# Altium schematic sheet 07_LMS7002M_Power.SchDoc(07_LMS7002M_Power)
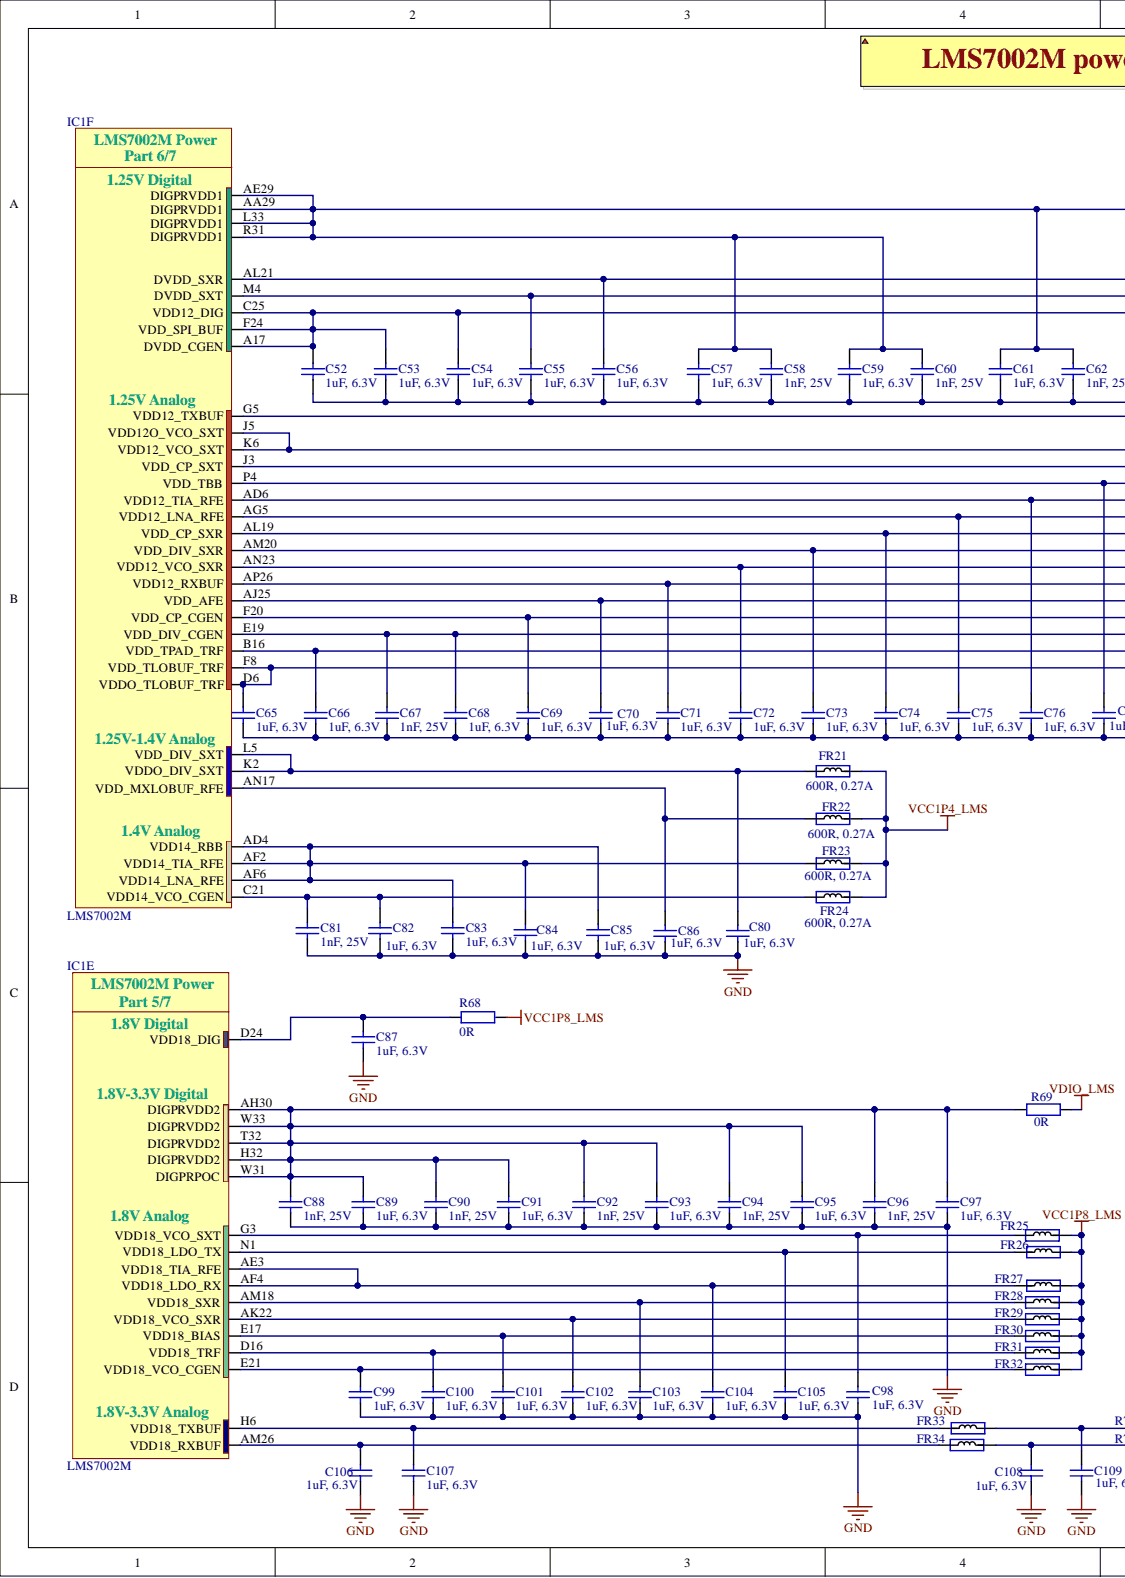
[diagram p0: 07_LMS7002M_Power.SchDoc, left]
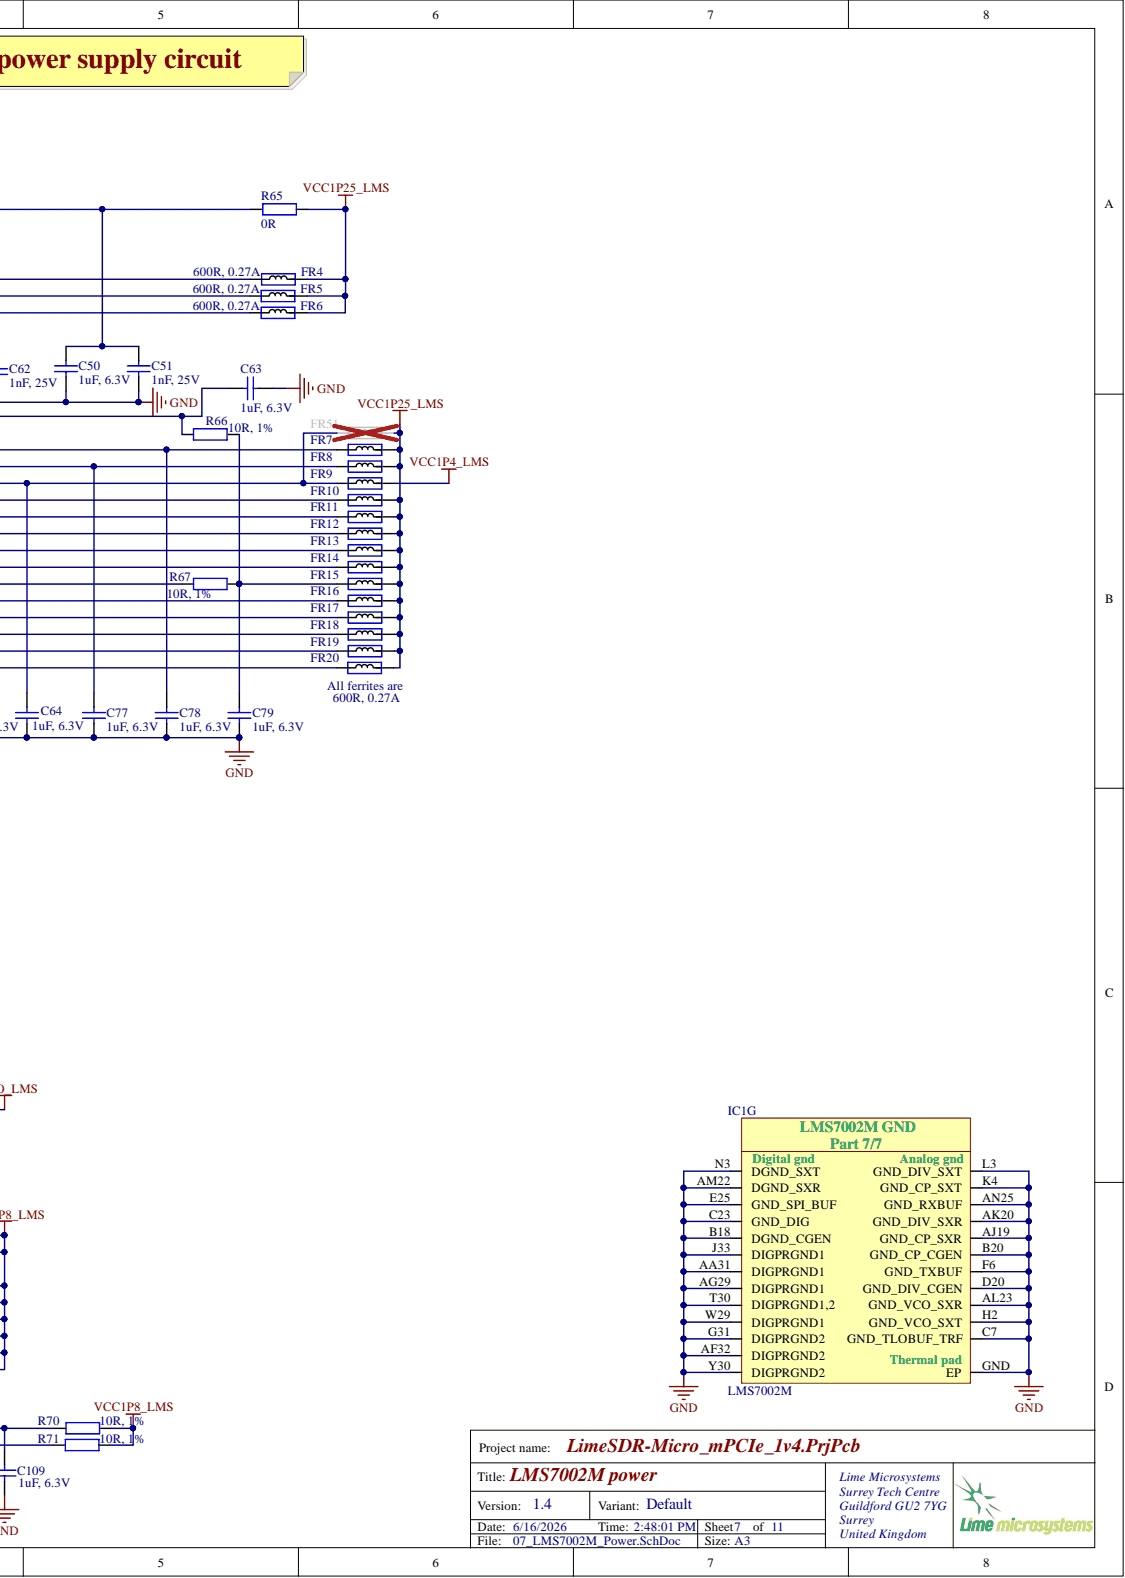
[diagram p1: 07_LMS7002M_Power.SchDoc, right]

## Parts
C50  1uF, 6.3V  [CAP0201]
C51  1nF, 25V  Voltage - Rated: 25V  [CAP0201]
C52  1uF, 6.3V  [CAP0201]
C53  1uF, 6.3V  Voltage - Rated: 6.3V  [CAP0201]
C54  1uF, 6.3V  Voltage - Rated: 6.3V  [CAP0201]
C55  1uF, 6.3V  Voltage - Rated: 6.3V  [CAP0201]
C56  1uF, 6.3V  Voltage - Rated: 6.3V  [CAP0201]
C57  1uF, 6.3V  [CAP0201]
C58  1nF, 25V  [CAP0201]
C59  1uF, 6.3V  [CAP0201]
C60  1nF, 25V  [CAP0201]
C61  1uF, 6.3V  [CAP0201]
C62  1nF, 25V  [CAP0201]
C63  1uF, 6.3V  Voltage - Rated: 6.3V  [CAP0201]
C64  1uF, 6.3V  Voltage - Rated: 6.3V  [CAP0201]
C65  1uF, 6.3V  [CAP0201]
C66  1uF, 6.3V  Voltage - Rated: 6.3V  [CAP0201]
C67  1nF, 25V  [CAP0201]
C68  1uF, 6.3V  [CAP0201]
C69  1uF, 6.3V  Voltage - Rated: 6.3V  [CAP0201]
C70  1uF, 6.3V  Voltage - Rated: 6.3V  [CAP0201]
C71  1uF, 6.3V  Voltage - Rated: 6.3V  [CAP0201]
C72  1uF, 6.3V  Voltage - Rated: 6.3V  [CAP0201]
C73  1uF, 6.3V  Voltage - Rated: 6.3V  [CAP0201]
C74  1uF, 6.3V  Voltage - Rated: 6.3V  [CAP0201]
C75  1uF, 6.3V  Voltage - Rated: 6.3V  [CAP0201]
C76  1uF, 6.3V  Voltage - Rated: 6.3V  [CAP0201]
C77  1uF, 6.3V  Voltage - Rated: 6.3V  [CAP0201]
C78  1uF, 6.3V  Voltage - Rated: 6.3V  [CAP0201]
C79  1uF, 6.3V  Voltage - Rated: 6.3V  [CAP0201]
C80  1uF, 6.3V  Voltage - Rated: 6.3V  [CAP0201]
C81  1nF, 25V  [CAP0201]
C82  1uF, 6.3V  [CAP0201]
C83  1uF, 6.3V  Voltage - Rated: 6.3V  [CAP0201]
C84  1uF, 6.3V  Voltage - Rated: 6.3V  [CAP0201]
C85  1uF, 6.3V  Voltage - Rated: 6.3V  [CAP0201]
C86  1uF, 6.3V  Voltage - Rated: 6.3V  [CAP0201]
C87  1uF, 6.3V  Voltage - Rated: 6.3V  [CAP0201]
C88  1nF, 25V  [CAP0201]
C89  1uF, 6.3V  [CAP0201]
C90  1nF, 25V  [CAP0201]
C91  1uF, 6.3V  [CAP0201]
C92  1nF, 25V  [CAP0201]
C93  1uF, 6.3V  [CAP0201]
C94  1nF, 25V  [CAP0201]
C95  1uF, 6.3V  [CAP0201]
C96  1nF, 25V  [CAP0201]
C97  1uF, 6.3V  [CAP0201]
C98  1uF, 6.3V  Voltage - Rated: 6.3V  [CAP0201]
C99  1uF, 6.3V  [CAP0201]
C100  1uF, 6.3V  Voltage - Rated: 6.3V  [CAP0201]
C101  1uF, 6.3V  Voltage - Rated: 6.3V  [CAP0201]
C102  1uF, 6.3V  Voltage - Rated: 6.3V  [CAP0201]
C103  1uF, 6.3V  Voltage - Rated: 6.3V  [CAP0201]
C104  1uF, 6.3V  Voltage - Rated: 6.3V  [CAP0201]
C105  1uF, 6.3V  Voltage - Rated: 6.3V  [CAP0201]
C106  1uF, 6.3V  Voltage - Rated: 6.3V  [CAP0201]
C107  1uF, 6.3V  Voltage - Rated: 6.3V  [CAP0201]
C108  1uF, 6.3V  Voltage - Rated: 6.3V  [CAP0201]
C109  1uF, 6.3V  Voltage - Rated: 6.3V  [CAP0201]
FR4  600R, 0.27A  [RES0201]
FR5  600R, 0.27A  [RES0201]
FR6  600R, 0.27A  [RES0201]
FR7  600R, 0.27A  [RES0201]
FR8  600R, 0.27A  [RES0201]
FR9  600R, 0.27A  [RES0201]
FR10  600R, 0.27A  [RES0201]
FR11  600R, 0.27A  [RES0201]
FR12  600R, 0.27A  [RES0201]
FR13  600R, 0.27A  [RES0201]
FR14  600R, 0.27A  [RES0201]
FR15  600R, 0.27A  [RES0201]
FR16  600R, 0.27A  [RES0201]
FR17  600R, 0.27A  [RES0201]
FR18  600R, 0.27A  [RES0201]
FR19  600R, 0.27A  [RES0201]
FR20  600R, 0.27A  [RES0201]
FR21  600R, 0.27A  [RES0201]
FR22  600R, 0.27A  [RES0201]
FR23  600R, 0.27A  [RES0201]
FR24  600R, 0.27A  [RES0201]
FR25  600R, 0.27A  [RES0201]
FR26  600R, 0.27A  [RES0201]
FR27  600R, 0.27A  [RES0201]
FR28  600R, 0.27A  [RES0201]
FR29  600R, 0.27A  [RES0201]
FR30  600R, 0.27A  [RES0201]
FR31  600R, 0.27A  [RES0201]
FR32  600R, 0.27A  [RES0201]
FR33  600R, 0.27A  [RES0201]
FR34  600R, 0.27A  [RES0201]
FR51  600R, 0.27A  [RES0201]
IC1  LMS7002M  [LMS7002M_v5_LESS_SILK]  (parts E,F,G)
R65  0R  [RES0402]
R66  10R, 1%  [RES0201]
R67  10R, 1%  [RES0201]
R68  0R  [RES0201]
R69  0R  [RES0402]
R70  10R, 1%  [RES0201]
R71  10R, 1%  [RES0201]

## Nets
GND: C50.2 C51.2 C52.2 C53.2 C54.2 C55.1 C56.2 C57.2 C58.2 C59.2 C60.2 C61.2 C62.2 C63.2 C64.2 C65.2 C66.2 C67.2 C68.2 C69.1 C70.2 C71.2 C72.2 C73.2 C74.2 C75.2 C76.1 C77.2 C78.1 C79.1 C80.2 C81.2 C82.2 C83.2 C84.2 C85.2 C86.2 C87.2 C88.2 C89.2 C90.2 C91.2 C92.2 C93.2 C94.2 C95.2 C96.2 C97.2 C98.2 C99.2 C100.2 C101.2 C102.2 C103.2 C104.2 C105.2 C106.2 C107.2 C108.2 C109.2 IC1.AA31(DIGPRGND1) IC1.AF32(DIGPRGND2) IC1.AG29(DIGPRGND1) IC1.AJ19(GND_CP_SXR) IC1.AK20(GND_DIV_SXR) IC1.AL23(GND_VCO_SXR) IC1.AM22(DGND_SXR) IC1.AN25(GND_RXBUF) IC1.B18(DGND_CGEN) IC1.B20(GND_CP_CGEN) IC1.C7(GND_TLOBUF_TRF) IC1.C23(GND_DIG) IC1.D20(GND_DIV_CGEN) IC1.E25(GND_SPI_BUF) IC1.F6(GND_TXBUF) IC1.G31(DIGPRGND2) IC1.GND(EP) IC1.H2(GND_VCO_SXT) IC1.J33(DIGPRGND1) IC1.K4(GND_CP_SXT) IC1.L3(GND_DIV_SXT) IC1.N3(DGND_SXT) IC1.T30(DIGPRGND1,2) IC1.W29(DIGPRGND1) IC1.Y30(DIGPRGND2)
VCC1P4_LMS: FR9.2 FR21.2 FR22.2 FR23.2 FR24.2
VCC1P8_LMS: FR25.2 FR26.1 FR27.1 FR28.2 FR29.2 FR30.2 FR31.2 FR32.2 R68.2 R70.2 R71.1
VCC1P25_LMS: FR4.2 FR5.2 FR6.2 FR7.2 FR8.2 FR10.2 FR11.2 FR12.2 FR13.2 FR14.2 FR15.2 FR16.2 FR17.2 FR18.2 FR19.2 FR20.2 FR51.2 R65.1
VDIO_LMS: R69.1
NetC50_1: C50.1 C51.1 C57.1 C58.1 C59.1 C60.1 C61.1 C62.1 IC1.AA29(DIGPRVDD1) IC1.AE29(DIGPRVDD1) IC1.L33(DIGPRVDD1) IC1.R31(DIGPRVDD1) R65.2
NetC52_1: C52.1 C53.1 C54.1 FR6.1 IC1.A17(DVDD_CGEN) IC1.C25(VDD12_DIG) IC1.F24(VDD_SPI_BUF)
NetC55_2: C55.2 FR5.1 IC1.M4(DVDD_SXT)
NetC56_1: C56.1 FR4.1 IC1.AL21(DVDD_SXR)
NetC63_1: C63.1 IC1.G5(VDD12_TXBUF) R66.1
NetC64_1: C64.1 FR9.1 FR51.1 IC1.P4(VDD_TBB)
NetC65_1: C65.1 FR20.1 IC1.D6(VDDO_TLOBUF_TRF) IC1.F8(VDD_TLOBUF_TRF)
NetC66_1: C66.1 FR19.1 IC1.B16(VDD_TPAD_TRF)
NetC67_1: C67.1 C68.1 FR18.1 IC1.E19(VDD_DIV_CGEN)
NetC69_2: C69.2 FR17.1 IC1.F20(VDD_CP_CGEN)
NetC70_1: C70.1 FR16.1 IC1.AJ25(VDD_AFE)
NetC71_1: C71.1 IC1.AP26(VDD12_RXBUF) R67.1
NetC72_1: C72.1 FR14.1 IC1.AN23(VDD12_VCO_SXR)
NetC73_1: C73.1 FR13.1 IC1.AM20(VDD_DIV_SXR)
NetC74_1: C74.1 FR12.1 IC1.AL19(VDD_CP_SXR)
NetC75_1: C75.1 FR11.1 IC1.AG5(VDD12_LNA_RFE)
NetC76_2: C76.2 FR10.1 IC1.AD6(VDD12_TIA_RFE)
NetC77_1: C77.1 FR8.1 IC1.J3(VDD_CP_SXT)
NetC78_2: C78.2 FR7.1 IC1.J5(VDD12O_VCO_SXT) IC1.K6(VDD12_VCO_SXT)
NetC79_2: C79.2 FR15.1 R66.2 R67.2
NetC80_1: C80.1 FR21.1 IC1.K2(VDDO_DIV_SXT) IC1.L5(VDD_DIV_SXT)
NetC81_1: C81.1 C82.1 FR24.1 IC1.C21(VDD14_VCO_CGEN)
NetC83_1: C83.1 C84.1 C85.1 FR23.1 IC1.AD4(VDD14_RBB) IC1.AF2(VDD14_TIA_RFE) IC1.AF6(VDD14_LNA_RFE)
NetC86_1: C86.1 FR22.1 IC1.AN17(VDD_MXLOBUF_RFE)
NetC87_1: C87.1 IC1.D24(VDD18_DIG) R68.1
NetC88_1: C88.1 C89.1 C90.1 C91.1 C92.1 C93.1 C94.1 C95.1 C96.1 C97.1 IC1.AH30(DIGPRVDD2) IC1.H32(DIGPRVDD2) IC1.T32(DIGPRVDD2) IC1.W31(DIGPRPOC) IC1.W33(DIGPRVDD2) R69.2
NetC98_1: C98.1 FR25.1 IC1.G3(VDD18_VCO_SXT)
NetC99_1: C99.1 FR32.1 IC1.E21(VDD18_VCO_CGEN)
NetC100_1: C100.1 FR31.1 IC1.D16(VDD18_TRF)
NetC101_1: C101.1 FR30.1 IC1.E17(VDD18_BIAS)
NetC102_1: C102.1 FR29.1 IC1.AK22(VDD18_VCO_SXR)
NetC103_1: C103.1 FR28.1 IC1.AM18(VDD18_SXR)
NetC104_1: C104.1 FR27.2 IC1.AE3(VDD18_TIA_RFE) IC1.AF4(VDD18_LDO_RX)
NetC105_1: C105.1 FR26.2 IC1.N1(VDD18_LDO_TX)
NetC106_1: C106.1 FR34.1 IC1.AM26(VDD18_RXBUF)
NetC107_1: C107.1 FR33.2 IC1.H6(VDD18_TXBUF)
NetC108_1: C108.1 FR34.2 R71.2
NetC109_1: C109.1 FR33.1 R70.1

## Library data of the parts
C50 C52-C57 C59 C61 C63-C66 C68-C80 C82-C87 C89 C91 C93 C95 C97-C109 = Cap_0402: Part Description: 1µF ±20% 6.3V X5R Ceramic Capacitor -55°C ~ 85°C Surface Mount, MLCC 0201 (0603 Metric) 0.024" L x 0.012" W (0.60mm x 0.30mm); Manufacturer Part Number 1: JMK063ABJ105MP-F; Manufacturer: TDK Corporation; Value: 1uF, 20%; Tolerance: ±20%; Temperature Coefficient: X5R; Capacitance: 1.0µF; Thickness (Max): -; Supplier Part Number 1: 587-5864-2-ND; Supplier 1: Digi-Key; Size / Dimension: 0.024" L x 0.012" W (0.60mm x 0.30mm); RoHS: RoHS Compliant; Ratings: -; Packaging: Cut Tape (CT); Package / Case: 0201 (0603 Metric); Operating Temperature: -55°C ~ 85°C; Mounting Type: Surface Mount, MLCC; Lead Style: -; Lead Spacing: -; Height - Seated (Max): -; Features: -; Component Kind: Standard; Category: Capacitors; Applications: General Purpose; Library Name: LimeMicroAltiumLib_schLib.SchLib
  C50 C52 C57 C59 C61 C65 C68 C82 C89 C91 C93 C95 C97 C99: Voltage - Rated: 6.3V
C51 C58 C60 C62 C67 C81 C88 C90 C92 C94 C96 = Cap_0402: Part Description: 1000pF ±10% 25V X7R Ceramic Capacitor -55°C ~ 125°C Surface Mount, MLCC 0201 (0603 Metric) 0.024" L x 0.012" W (0.60mm x 0.30mm); Manufacturer Part Number 1: C0603X7R1E102K030BA; Manufacturer: TDK Corporation; Tolerance: ±10%; Temperature Coefficient: X7R; Capacitance: 1000pF; Value: 1nF, 25V; Thickness (Max): 0.013" (0.33mm); Supplier Part Number 1: 445-7275-1-ND; Supplier 1: Digi-Key; Size / Dimension: 0.024" L x 0.012" W (0.60mm x 0.30mm); RoHS: RoHS Compliant; Ratings: -; Packaging: Cut Tape (CT); Package / Case: 0201 (0603 Metric); Operating Temperature: -55°C ~ 125°C; Mounting Type: Surface Mount, MLCC; Lead Style: -; Lead Spacing: -; Height - Seated (Max): -; Features: -; Component Kind: Standard; Category: Capacitors; Applications: General Purpose; Library Name: LimeMicroAltiumLib_schLib.SchLib
  C58 C60 C62 C67 C81 C88 C90 C92 C94 C96: Voltage - Rated: 25V
FR4-FR34 FR51 = Ferrite: Part Description: FERRITE BEAD 600 OHM 0201 1LN; Manufacturer Part Number 1: BK0603TS601-T; Manufacturer: Taiyo Yuden; Value: 600R@100MHz; Impedance @ Frequency: 600 Ohm @ 100MHz; Filter Type: Differential Mode - Single; DC Resistance (DCR): 750 mOhm Max; Current Rating: 270mA; Supplier Part Number 1: 587-3277-1-ND; Supplier 1: Digi-Key; Size / Dimension: 0.024" L x 0.012" W (0.60mm x 0.30mm); RoHS: RoHS Compliant; Packaging: Cut Tape (CT); Package / Case: 0201 (0603 Metric); Mounting Type: Surface Mount; Height (Max): 0.013" (0.33mm); Component Kind: Standard; Category: Filters; Library Name: LimeMicroAltiumLib_schLib.SchLib
IC1 = LMS7002Mr4: Schematic symbol revision 4. Default footprint - 0.31mm pad; Part Description: IC FPRF Transceiver LMS7002M; 261 pin aQFN; Manufacturer: Lime Microsystems; Supplier Part Number 1: LMS7002M; Supplier 1: Lime Microsystems; Manufacturer Part Number 1: LMS7002M; Library Name: LimeMicroAltiumLib_schLib_r04.SchLib; Component Kind: Standard
R65 R69 = Res_0402: Part Description: RES SMD 0.0OHM JUMPER 1/16W 0402; Manufacturer Part Number 1: RC0402JR-070RL; Manufacturer: Yageo; Tolerance: Jumper; Resistance (Ohms): 0.0; Power (Watts): 0.063W, 1/16W; Value: 0R; Temperature Coefficient: -; Supplier Part Number 1: 311-0.0JRTR-ND; Supplier Device Package: 0402; Supplier 1: Digi-Key; Size / Dimension: 0.039" L x 0.020" W (1.00mm x 0.50mm); RoHS: RoHS Compliant; Packaging: Tape & Reel (TR); Package / Case: 0402 (1005 Metric); Operating Temperature: -55°C ~ 155°C; Number of Terminations: 2; Height: 0.016" (0.40mm); Features: Moisture Resistant; Composition: Thick Film; Component Kind: Standard; Category: Resistors; Library Name: LimeMicroAltiumLib_schLib.SchLib
R66 R67 R70 R71 = Res_0402: Part Description: RES SMD 10 OHM 1% 1/20W 0201; Manufacturer Part Number 1: ERJ-1GEF10R0C; Manufacturer: Panasonic Electronic Components; Temperature Coefficient: ±200ppm/°C; Resistance (Ohms): 10; Power (Watts): 0.05W, 1/20W; Value: 10R, 1%; Tolerance: ±1%; Supplier Part Number 1: P10.0ABCT-ND; Supplier Device Package: 0201; Supplier 1: Digi-Key; Size / Dimension: 0.024" L x 0.012" W (0.60mm x 0.30mm); RoHS: RoHS Compliant; Packaging: Cut Tape (CT); Package / Case: 0201 (0603 Metric); Operating Temperature: -55°C ~ 125°C; Number of Terminations: 2; Height: 0.010" (0.26mm); Features: -; Composition: Thick Film; Component Kind: Standard; Category: Resistors; Library Name: LimeMicroAltiumLib_schLib.SchLib
R68 = Res_0402: Part Description: 0 Ohms Jumper Chip Resistor 0201 (0603 Metric) Moisture Resistant Thick Film; Manufacturer Part Number 1: RC0201JR-070RL; Manufacturer: Yageo; Voltage Rating (DC): 25V; Tolerance: 5%; Power Rating: 50mW; Width: 0.012inch; Weight: 6E-06oz; Voltage Rating: 25V; Value: 0R; Termination: SMD/SMT; Supplier Part Number 1: 311-0.0NCT-ND; Supplier 1: Digi-Key; Stock 1: 6252381; Size Code: 0201; Series: RC; RoHS Compliant: Yes; RoHS: Yes; Resistor Type: Thick Film; Resistance Tolerance: 5%; Resistance: 0R; Radiation Hardening: No; Pricing 1: 1=0,10000, 10=0,03700, 25=0,02680, 50=0,02020, 100=0,01500, 250=0,01140, 500=0,00912, 1000=0,00672, 5000=0,00482 (USD); Packaging: Tape and Reel; Package Quantity: 1; Number of Terminations: 2; Min Operating Temperature: -55°C; Military Standard: Not; Max Power Dissipation: 50mW; Max Operating Temperature: 125°C; Length: 0.024inch; Lead Free: Lead Free; Height: 0.01inch; Features: Moisture Resistant; Depth: 0.3mm; Contact Plating: Tin,Matte; Construction: Rectangular; ComponentLink5URL: https://datasheet.ciiva.com/46391/1421516-46391340.pdf?src-supplier=Element14; ComponentLink5Description: https://datasheet.ciiva.com/46391/1421516-46391340.pdf?src-supplier=Element14; ComponentLink4URL: https://datasheet.ciiva.com/7660/1421516-7660808.pdf?src-supplier=Element14; ComponentLink4Description: https://datasheet.ciiva.com/7660/1421516-7660808.pdf?src-supplier=Element14; ComponentLink3URL: https://datasheet.ciiva.com/7672/1563626-7672247.pdf?src-supplier=Element14; ComponentLink3Description: https://datasheet.ciiva.com/7672/1563626-7672247.pdf?src-supplier=Element14; ComponentLink2URL: https://datasheet.ciiva.com/7672/1563626-7672248.pdf?src-supplier=Element14; ComponentLink2Description: https://datasheet.ciiva.com/7672/1563626-7672248.pdf?src-supplier=Element14; ComponentLink1URL: http://www.yageo.com/documents/recent/PYu-RC_Group_51_RoHS_L_7.pdf; ComponentLink1Description: http://www.yageo.com/documents/recent/PYu-RC_Group_51_RoHS_L_7.pdf; Component Kind: Standard; Case Code (Metric): 0603; Case Code (Imperial): 0201; Case/Package: 0201; Library Name: LimeMicroAltiumLib_schLib.SchLib
